# Altium schematic sheet schmatic.SchDoc
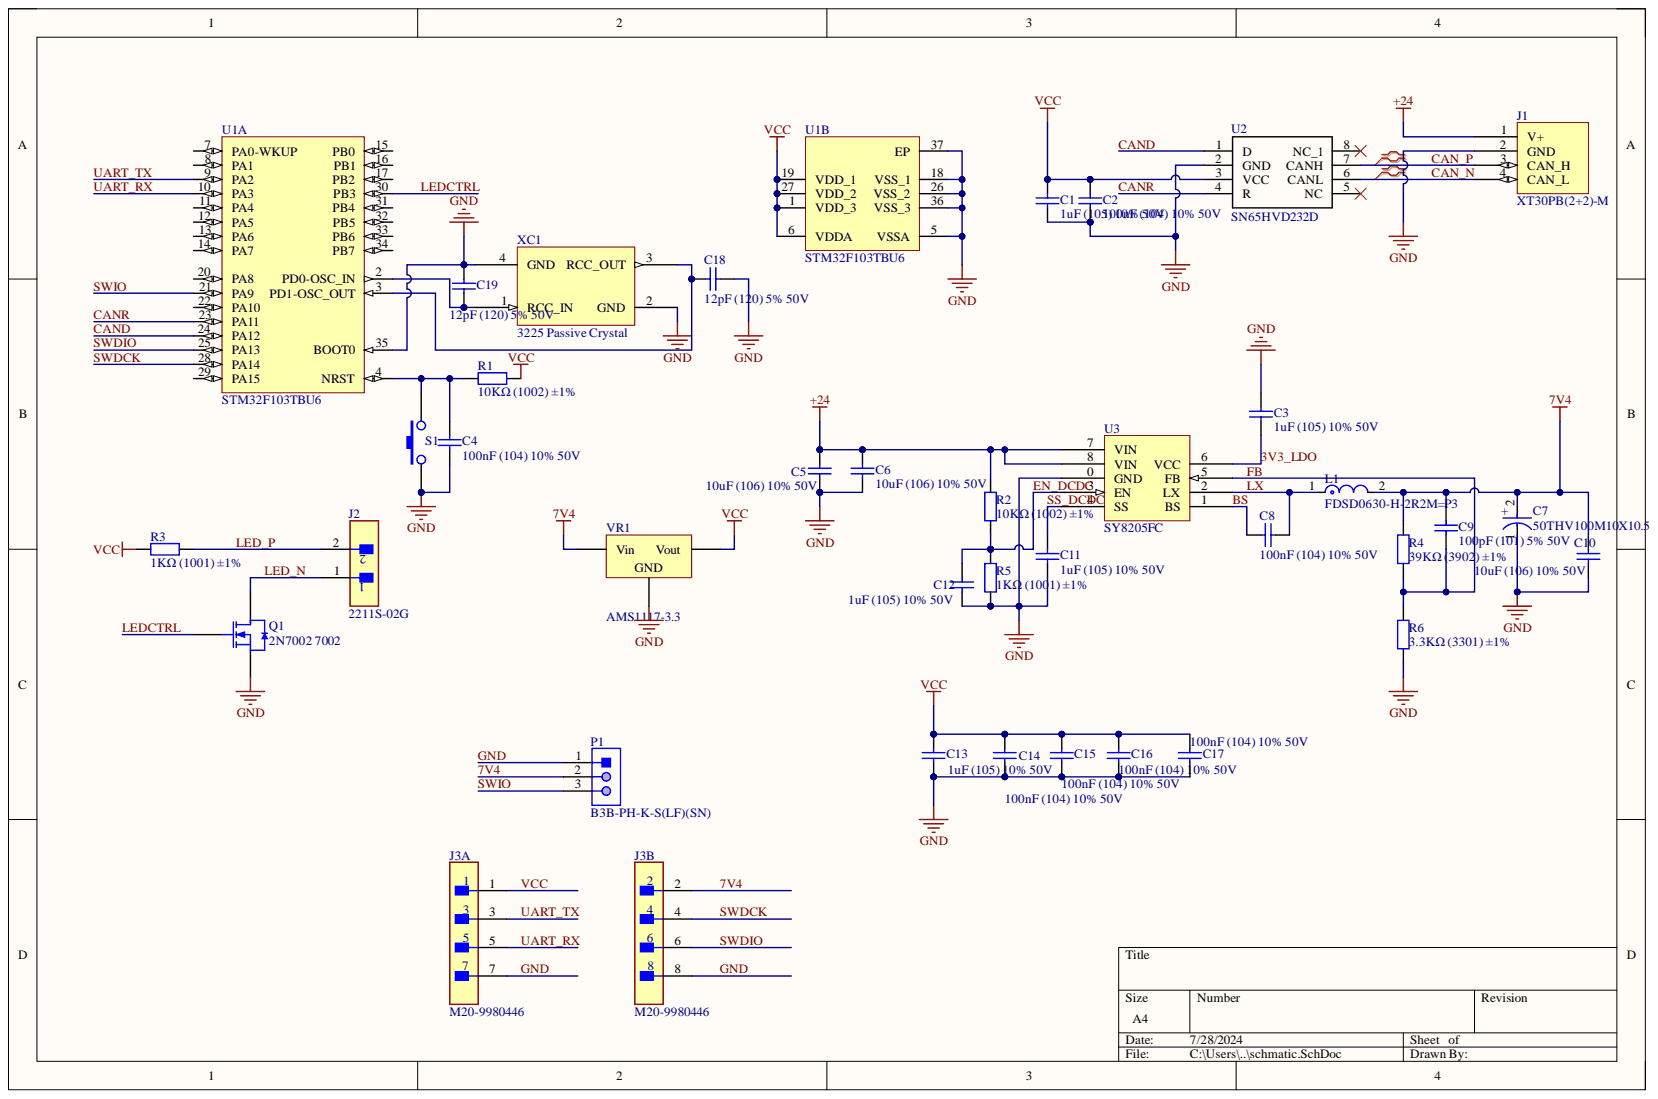
[diagram p0: schmatic.SchDoc]

## Parts
C1  1uF (105) 10% 50V  [0603_C]
C2  100nF (104) 10% 50V  [0603_C]
C3  1uF (105) 10% 50V  [0603_C]
C4  100nF (104) 10% 50V  [0603_C]
C5  10uF (106) 10% 50V  [1206_C]
C6  10uF (106) 10% 50V  [1206_C]
C7  50THV100M10X10.5  [FP-THV10X10_5-MFG]
C8  100nF (104) 10% 50V  [0603_C]
C9  100pF (101) 5% 50V  [0603_C]
C10  10uF (106) 10% 50V  [1206_C]
C11  1uF (105) 10% 50V  [0603_C]
C12  1uF (105) 10% 50V  [0603_C]
C13  1uF (105) 10% 50V  [0603_C]
C14  100nF (104) 10% 50V  [0603_C]
C15  100nF (104) 10% 50V  [0603_C]
C16  100nF (104) 10% 50V  [0603_C]
C17  100nF (104) 10% 50V  [0603_C]
C18  12pF (120) 5% 50V  [0603_C]
C19  12pF (120) 5% 50V  [0603_C]
J1  XT30PB(2+2)-M  [XT30PB(2+2)-M-Footprint-1]
J2  2211S-02G  [FP-2211S-02G-MFG]
J3  M20-9980446  [FP-M20-9980446-MFG]  (parts A,B)
L1  FDSD0630-H-2R2M=P3  [FP-FDSD0630-MFG]
P1  B3B-PH-K-S(LF)(SN)  [JST-B3B-PH-K-S-3_V]
Q1  2N7002 7002  [SOT-23(SOT-23-3)]
R1  10KΩ (1002) ±1%  [0603_R]
R2  10KΩ (1002) ±1%  [0603_R]
R3  1KΩ (1001) ±1%  [0603_R]
R4  39KΩ (3902) ±1%  [0603_R]
R5  1KΩ (1001) ±1%  [0603_R]
R6  3.3KΩ (3301) ±1%  [0603_R]
S1  按键  [SWT3*4]  (value not drawn)
U1  STM32F103TBU6  [STM-VFQFPN36_L]  (parts A,B)
U2  SN65HVD232D  [SOIC127P600X175-8N]
U3  SY8205FC  [SOP-8_EP_150mil]
VR1  AMS1117-3.3  [SOT-223]
XC1  3225 Passive Crystal  [SMD-3225]

## Nets
+24: C5.2 C6.2 J1.1(V+) R2.2 U3.7(VIN) U3.8(VIN)
3V3_LDO: C3.1 U3.6(VCC)
7V4: C7.2 C9.2 C10.2 J3.2 L1.2 P1.2 R4.2 VR1.3
BS: C8.1 U3.1(BS)
CAN_N: J1.4(CAN_L) U2.6(CANL)
CAN_P: J1.3(CAN_H) U2.7(CANH)
CAND: U1.24(PA12) U2.1(D)
CANR: U1.23(PA11) U2.4(R)
EN_DCDC: C12.2 R2.1 R5.2 U3.3(EN)
FB: C9.1 R4.1 R6.2 U3.5(FB)
GND: C1.1 C2.1 C3.2 C4.1 C5.1 C6.1 C7.1 C10.1 C11.1 C12.1 C13.1 C14.1 C15.1 C16.1 C17.1 C18.2 C19.2 J1.2(GND) J3.7 J3.8 P1.1 Q1.2 R5.1 R6.1 S1.1 U1.5(VSSA) U1.18(VSS_1) U1.26(VSS_2) U1.35(BOOT0) U1.36(VSS_3) U1.37(EP) U2.2(GND) U3.0(GND) VR1.1 XC1.2(GND) XC1.4(GND)
LED_N: J2.1 Q1.3
LED_P: J2.2 R3.1
LEDCTRL: Q1.1 U1.30(PB3)
LX: C8.2 L1.1 U3.2(LX)
SS_DCDC: C11.2 U3.4(SS)
SWDCK: J3.4 U1.28(PA14)
SWDIO: J3.6 U1.25(PA13)
SWIO: P1.3 U1.21(PA9)
UART_RX: J3.5 U1.10(PA3)
UART_TX: J3.3 U1.9(PA2)
VCC: C1.2 C2.2 C13.2 C14.2 C15.2 C16.2 C17.2 J3.1 R1.2 R3.2 U1.1(VDD_3) U1.6(VDDA) U1.19(VDD_1) U1.27(VDD_2) U2.3(VCC) VR1.2
NetC4_2: C4.2 R1.1 S1.2 U1.4(NRST)
NetC18_1: C18.1 U1.3(PD1-OSC_OUT) XC1.3(RCC_OUT)
NetC19_1: C19.1 U1.2(PD0-OSC_IN) XC1.1(RCC_IN)

Not connected: U1 7(PA0-WKUP) 8(PA1) 11(PA4) 12(PA5) 13(PA6) 14(PA7) 15(PB0) 16(PB1) 17(PB2) 20(PA8) 22(PA10) 29(PA15) 31(PB4) 32(PB5) 33(PB6) 34(PB7); U2 5(NC) 8(NC_1)

## Library data of the parts
C1 C3 C11-C13 = 0603 1uF (105) 10% 50V: 贴片电容; Component Kind: Standard; ComponentLink1Description: 供应商链接; ComponentLink1URL: http://www.szlcsc.com/product/details_16531.html; Library Name: Miscellaneous Devices LC.IntLib; Package: 0603; Supplier: LC; SuppliersPartNumber: C15849
C2 C4 C8 C14-C17 = 0603 100nF (104) 10% 50V: 贴片电容; Component Kind: Standard; ComponentLink1Description: 供应商链接; ComponentLink1URL: http://www.szlcsc.com/product/details_15331.html; Library Name: Miscellaneous Devices LC.IntLib; Package: 0603; Supplier: LC; SuppliersPartNumber: C14663
C5 C6 C10 = 1206 10uF (106) 10% 50V: 贴片电容; Component Kind: Standard; ComponentLink1Description: 供应商链接; ComponentLink1URL: http://www.szlcsc.com/product/details_14236.html; Library Name: Miscellaneous Devices LC.IntLib; Package: 1206; Supplier: LC; SuppliersPartNumber: C13585
C7 = CMP-35376-000015-1: CAP ALUM 100UF 20% 50V SMD; ESR (Equivalent Series Resistance): 250mR; Life (Hours): 3000Hour; Ripple Current: 175mA; Ripple Current (AC): 350mA; Capacitance: 100uF; Case/Package: Radial; Component Kind: Standard; Construction: Cylindrical; Diameter: 10mm; Height: 10.5mm; Height - Seated (Max): 0.413inch; Library Name: Altium Content Vault; Max Operating Temperature: 125°C; Min Operating Temperature: -40°C; Mount: Surface Mount; Package Quantity: 1; Packaging: Cut Tape; Radiation Hardening: No; REACH SVHC: No SVHC; RoHS Compliant: Yes; Series: THV; Termination: SMD/SMT; Tolerance: 20%; Voltage: 50V; Voltage Rating: 50V; Voltage Rating (DC): 50V
C9 = 0603 100pF (101) 5% 50V: 贴片电容; Component Kind: Standard; ComponentLink1Description: 供应商链接; ComponentLink1URL: http://www.szlcsc.com/product/details_15530.html; Library Name: Miscellaneous Devices LC.IntLib; Package: 0603; Supplier: LC; SuppliersPartNumber: C14858
C18 C19 = 0603 12pF (120) 5% 50V: 贴片电容; Component Kind: Standard; ComponentLink1Description: 供应商链接; ComponentLink1URL: http://www.szlcsc.com/product/details_39506.html; Library Name: Miscellaneous Devices LC.IntLib; Package: 0603; Supplier: LC; SuppliersPartNumber: C38523
J1 = CMP-002-00072-5: This is a power connector that can also be used to send/receive can signals; Gender: Male; Component Kind: Standard; Pins: 4; Library Name: Skyli Personal Workspace
J2 = CMP-12414-000006-2: 2.54mm pitch, single row PCB headers.; Gender: Male; Insulation Resistance: 1GR; Number of Contacts: 2; Component Kind: Standard; Contact Material: Copper Alloy; Contact Plating: Gold; Flammability Rating: UL94 V-0; Height: 0.47inch; Length: 0.2inch; Library Name: Altium Content Vault; Mount: Through Hole; Number of Rows: 1; REACH SVHC: No SVHC; RoHS Compliant: No; Termination: Through Hole; Weight: 0.11lb; Width: 0.12inch
J3 = CMP-15831-000088-1: CONN HEADER VERT 8POS 2.54MM; Connector Type: Male Pin; Contact Resistance: 30mR; Current Rating: 3A; Gender: Male; Insulation Resistance: 10GR; Mated Stacking Heights: 16.54mm; Number of Contacts: 8; Row Spacing: 0.1inch; Color: Black; Component Kind: Standard; Contact Finish - Mating: TIN; Contact Material: Brass; Contact Mating Length: 0.24inch; Contact Plating: Tin; Contact Shape: Square; Contact Type: Male Pin; Depth: 5.08mm; Fastening Type: Pull; Flammability Rating: UL94 V-0; Housing Color: Black; Housing Material: Nylon; Insulation Color: Black; Insulation Material: Nylon; Length: 10.16mm; Library Name: Altium Content Vault; Max Current Rating: 3A; Max Operating Temperature: 105°C; Min Operating Temperature: -40°C; Mount: Through Hole; Number of Positions: 8; Number of Rows: 2; Orientation: Straight; Package Quantity: 1000; Packaging: Bulk; Pitch: 2.54mm; Radiation Hardening: No; REACH SVHC: No SVHC; RoHS Compliant: Yes; Series: M20; Termination: Solder
L1 = CMP-06042-016400-1: FIXED IND 2.2UH 7.1A 17 MOHM SMD; Core Material: Ferrite; DC Resistance (DCR): 17mR; Saturation Current: 12A; Shielding: Shielded; Test Frequency: 100kHz; Tolerance: 20%; Case/Package: Nonstandard; Component Kind: Standard; Current Rating: 7.1A; Depth: 6.6mm; Height - Seated (Max): 0.118inch; Inductance: 2.2uH; Length: 0.276inch; Library Name: Altium Content Vault; Max DC Current: 7.1A; Mount: Surface Mount; Packaging: Tape and Reel; Resistor Type: Wirewound; RoHS Compliant: Yes; Width: 0.26inch
P1 = CMP-2000-05164-1: Male Header, Pitch 2 mm, 1 x 3 Position, Height 6 mm, Tail Length 3.4 mm, -25 to 85 degC, RoHS, Bulk; Manufacturer: JST; No of Positions: 3; Pitch: 2mm; Style/Orientation: StraightHeader/Plug; Component Kind: Standard; Datasheet URL: https://datasheet.ciiva.com/11746/0900766b80826550-11746939.pdf; Datasheet Version: Oct-06; Library Name: Altium Content Vault; Manufacturer URL: http://www.jst.com/home2.html; Termination: Crimp; Type: ShroudedHeader
Q1 = 2N7002 7002: MOS(场效应管); Component Kind: Standard; ComponentLink1Description: 供应商链接; ComponentLink1URL: http://www.szlcsc.com/product/details_9040.html; Library Name: Miscellaneous Devices LC.IntLib; Package: SOT-23(SOT-23-3); Supplier: LC; SuppliersPartNumber: C8545
R1 R2 = 0603 10KΩ (1002) 1%: 贴片电阻; Component Kind: Standard; ComponentLink1Description: 供应商链接; ComponentLink1URL: http://www.szlcsc.com/product/details_26547.html; Library Name: Miscellaneous Devices LC.IntLib; Package: 0603; Supplier: LC; SuppliersPartNumber: C25804
R3 R5 = 0603 1KΩ (1001) 1%: 贴片电阻; Component Kind: Standard; ComponentLink1Description: 供应商链接; ComponentLink1URL: http://www.szlcsc.com/product/details_21904.html; Library Name: Miscellaneous Devices LC.IntLib; Package: 0603; Supplier: LC; SuppliersPartNumber: C21190
R4 = 0603 39KΩ (3902) 1%: 贴片电阻; Component Kind: Standard; ComponentLink1Description: 供应商链接; ComponentLink1URL: http://www.szlcsc.com/product/details_23880.html; Library Name: Miscellaneous Devices LC.IntLib; Package: 0603; Supplier: LC; SuppliersPartNumber: C23153
R6 = 0603 3.3KΩ (3301) 1%: 贴片电阻; Component Kind: Standard; ComponentLink1Description: 供应商链接; ComponentLink1URL: http://www.szlcsc.com/product/details_23705.html; Library Name: Miscellaneous Devices LC.IntLib; Package: 0603; Supplier: LC; SuppliersPartNumber: C22978
S1 = Button: Component Kind: Standard; Library Name: libadp.IntLib
U1 = CMP-0237-00055-3: ARM Cortex-M3 32-bit MCU, 128 KB Flash, 20 KB Internal RAM, 26 I/Os, 36-pin VFQFPN, -40 to 85 degC, Tray; A/D Converter: 2x12-bit (10 channel); FLASH Size (Prog) (kB): 128; I/Os (High Current): 26; Internal RAM Size (kB): 20; Operating Frequency(F) (Processor speed) (MHz): 72; Supply Voltage(Vcc) min (V): 2; Component Kind: Standard; ComponentLink1Description: Manufacturer URL; ComponentLink1URL: http://www.st.com/web/en/home.html; ComponentLink2Description: Datasheet; ComponentLink2URL: http://www.st.com/st-web-ui/static/active/en/resource/technical/document/datasheet/CD00161566.pdf; ComponentLink3Description: Package Specification; ComponentLink3URL: http://www.st.com/st-web-ui/static/active/en/resource/technical/document/datasheet/CD00161561.pdf; Core: ARM Cortex-M3; DatasheetVersion: Rev. 16; Library Name: Altium Content Vault; Mounting Technology: Surface Mount; PackageDescription: 36-Pin Quad Flat No-Lead, 6 x 6 x 1.0 mm Body, 0.5 mm Pitch, Exposed Pad; PackageReference: VFQFPN36; PackageVersion: Rev. 16, 08/2013; Packing: Tray; PartNumber: STM32F103TBU6
U2 = SN65HVD232D: 3.3-V CAN TRANSCEIVER; Manufacturer_Name: Texas Instruments; Component Kind: Standard; Datasheet Link: http://www.ti.com/lit/ds/symlink/sn65hvd232.pdf; Height: 1.75mm; Manufacturer_Part_Number: SN65HVD232D; Mouser Part Number: 595-SN65HVD232D; Mouser Price/Stock: https://www.mouser.com/Search/Refine.aspx?Keyword=595-SN65HVD232D; RS Part Number: 1977506; RS Price/Stock: http://uk.rs-online.com/web/p/products/1977506; Library Name: libadp.IntLib
U3 = SY8205FC: 5A, 4.5V-30V Input, Synchronous Step Down Regulator (Buck); Component Kind: Standard; Library Name: libadp.IntLib
VR1 = SOT-223 AMS1117-3.3: 低压差线性稳压(LDO); Component Kind: Standard; ComponentLink1Description: 供应商链接; ComponentLink1URL: http://www.szlcsc.com/product/details_6652.html; Library Name: Miscellaneous Devices LC.IntLib; Package: SOT-223; Supplier: LC; SuppliersPartNumber: C6186
XC1 = 3225 Passive Crystal: Component Kind: Standard; Library Name: libadp.IntLib
